# Altium schematic sheet 10_USB.SchDoc(10_USB)
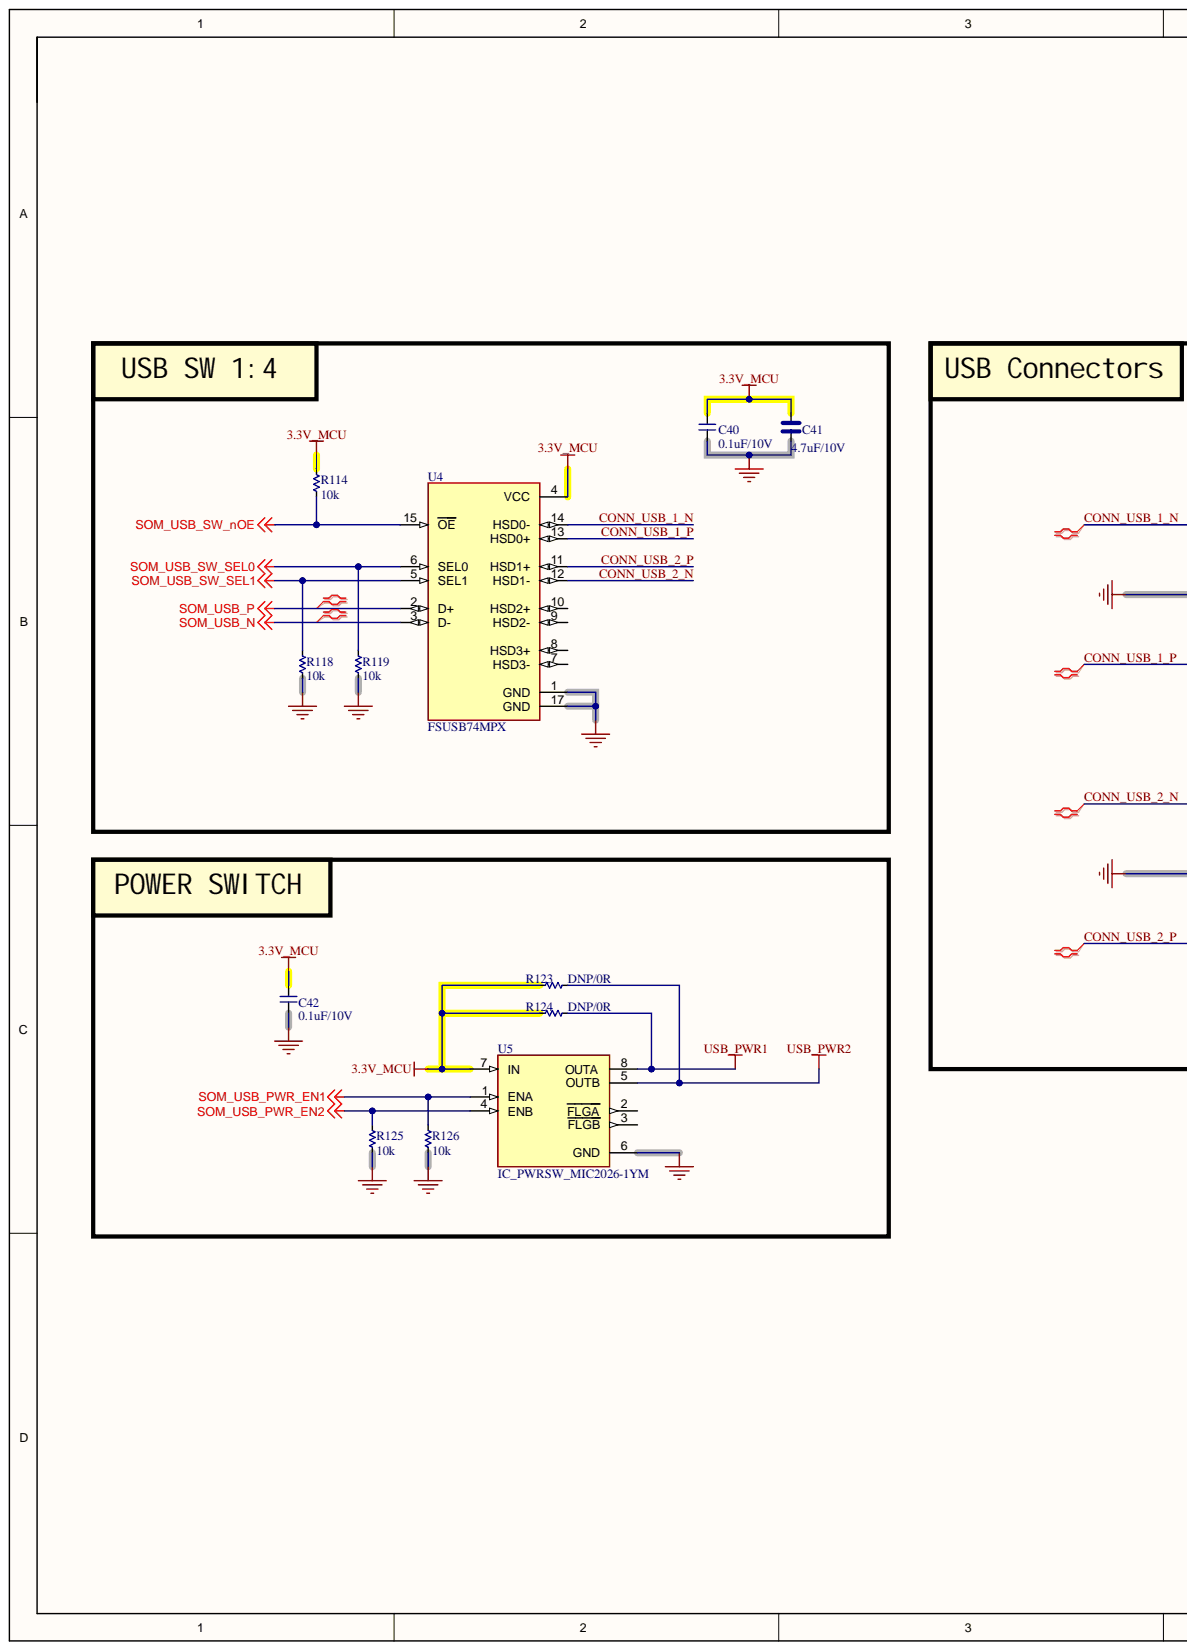
[diagram p0: 10_USB.SchDoc, left]
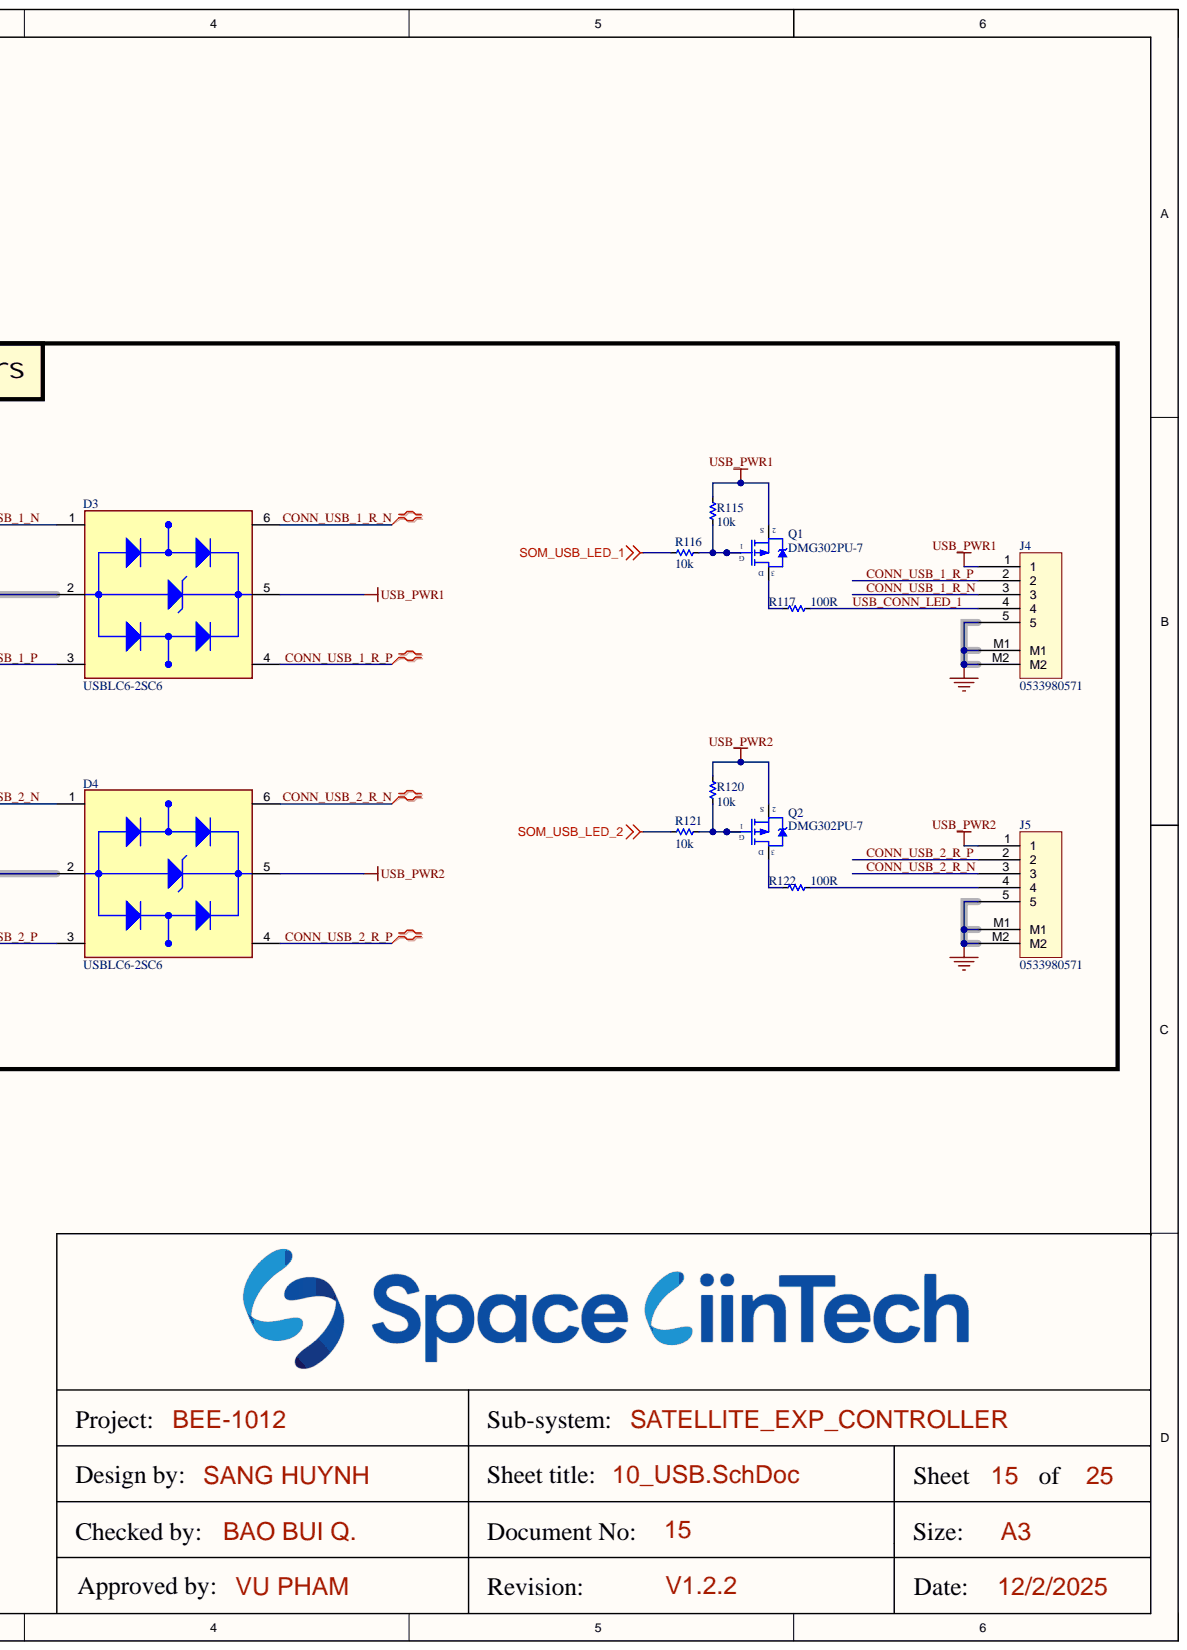
[diagram p1: 10_USB.SchDoc, right]

## Parts
C40  0.1uF/10V  [CAP_C_1005X60]
C41  4.7uF/10V  [CAP_C_1608X80]
C42  0.1uF/10V  [CAP_C_1005X60]
D3  USBLC6-2SC6  [SOT95P280X145-6N]
D4  USBLC6-2SC6  [SOT95P280X145-6N]
J4  0533980571  [MOLEX_0533980571]
J5  0533980571  [MOLEX_0533980571]
Q1  DMG302PU-7  [SOT95P240X120-3N]
Q2  DMG302PU-7  [SOT95P240X120-3N]
R114  10k  [RES_C_1005X40]
R115  10k  [RES_C_1005X40]
R116  10k  [RES_C_1005X40]
R117  100R  [RES_C_1005X40]
R118  10k  [RES_C_1005X40]
R119  10k  [RES_C_1005X40]
R120  10k  [RES_C_1005X40]
R121  10k  [RES_C_1005X40]
R122  100R  [RES_C_1005X40]
R123  DNP/0R  [RES_C_1005X40]
R124  DNP/0R  [RES_C_1005X40]
R125  10k  [RES_C_1005X40]
R126  10k  [RES_C_1005X40]
U4  FSUSB74MPX  [QFN50P300X300X80-17N]
U5  IC_PWRSW_MIC2026-1YM  [SOIC127P602X173-8N]

## Nets
3.3V_MCU: C40.2 C41.2 C42.2 R114.1 R123.2 R124.2 U4.4(VCC) U5.7(IN)
GND: C40.1 C41.1 C42.1 D3.2 D4.2 J4.5 J4.M1 J4.M2 J5.5 J5.M1 J5.M2 R118.2 R119.2 R125.2 R126.2 U4.1(GND) U4.17(GND) U5.6(GND)
SOM_USB_LED_1: R116.1
SOM_USB_LED_2: R121.1
SOM_USB_N: U4.3(D-)
SOM_USB_P: U4.2(D+)
SOM_USB_PWR_EN1: R126.1 U5.1(ENA)
SOM_USB_PWR_EN2: R125.1 U5.4(ENB)
SOM_USB_SW_nOE: R114.2 U4.15(OE)
SOM_USB_SW_SEL0: R119.1 U4.6(SEL0)
SOM_USB_SW_SEL1: R118.1 U4.5(SEL1)
USB_PWR1: D3.5 J4.1 Q1.2 R115.1 R124.1 U5.8(OUTA)
USB_PWR2: D4.5 J5.1 Q2.2 R120.1 R123.1 U5.5(OUTB)
CONN_USB_1_N: D3.1 U4.14(HSD0-)
CONN_USB_1_P: D3.3 U4.13(HSD0+)
CONN_USB_1_R_N: D3.6 J4.3
CONN_USB_1_R_P: D3.4 J4.2
CONN_USB_2_N: D4.1 U4.12(HSD1-)
CONN_USB_2_P: D4.3 U4.11(HSD1+)
CONN_USB_2_R_N: D4.6 J5.3
CONN_USB_2_R_P: D4.4 J5.2
USB_CONN_LED_1: J4.4 R117.2
NetJ5_4: J5.4 R122.2
NetQ1_1: Q1.1 R115.2 R116.2
NetQ1_3: Q1.3 R117.1
NetQ2_1: Q2.1 R120.2 R121.2
NetQ2_3: Q2.3 R122.1

Not connected: U4 7(HSD3-) 8(HSD3+) 9(HSD2-) 10(HSD2+); U5 2(FLGA) 3(FLGB)

## Library data of the parts
C40 C42 = _CAP_C_2: CAP CHIP; Component Kind: Standard; Library Name: LABLIB.SchLib
C41 = _CAP_C_High_2: CAP CHIP High Value; Component Kind: Standard; Library Name: LABLIB.SchLib
D3 D4 = USBLC6-2SC6: 17V Clamp 5A (8/20Âµs) Ipp Tvs Diode Surface Mount SOT-23-6; MF: STMicroelectronics; Availability: In Stock; Check_prices: https://www.snapeda.com/parts/USBLC6-2SC6/STMicroelectronics/view-part/?ref=eda; Component Kind: Standard; Library Name: USBLC6-2SC6.IntLib; MP: USBLC6-2SC6; Package: SOT-23-6 STMicroelectronics; Price: None; Purchase-URL: https://pricing.snapeda.com/search/part/USBLC6-2SC6/?ref=eda; SnapEDA_Link: https://www.snapeda.com/parts/USBLC6-2SC6/STMicroelectronics/view-part/?ref=snap
J4 J5 = Header 5x1_2M: Component Kind: Standard; Library Name: LABLIB.SchLib
Q1 Q2 = MOSFET_P_GSD: Component Kind: Standard; Library Name: LABLIB.SchLib
R114-R126 = _RES_B_2: RES; Component Kind: Standard; Library Name: LABLIB.SchLib
U4 = IC_USB_SW_FSUSB74MPX: USB Switch IC 1 Channel 16-MLP (3x3); MF: ON Semiconductor; Check_prices: https://www.snapeda.com/parts/FSUSB74MPX/Onsemi/view-part/?ref=eda; Component Kind: Standard; MP: FSUSB74MPX; Package: WQFN-16 ON Semiconductor; SnapEDA_Link: https://www.snapeda.com/parts/FSUSB74MPX/Onsemi/view-part/?ref=snap; Library Name: LABLIB.SchLib
U5 = IC_PWRSW_MIC2026-1YM: Power Switch/Driver 1:2 N-Channel 1.25A 8-SOIC; MF: Microchip; Check_prices: https://www.snapeda.com/parts/MIC2026-1YM/Microchip/view-part/?ref=eda; Component Kind: Standard; MP: MIC2026-1YM; Package: SO-8 Microchip; SnapEDA_Link: https://www.snapeda.com/parts/MIC2026-1YM/Microchip/view-part/?ref=snap; Library Name: LABLIB.SchLib
